# Altium schematic sheet RP2350_QSPI.SchDoc
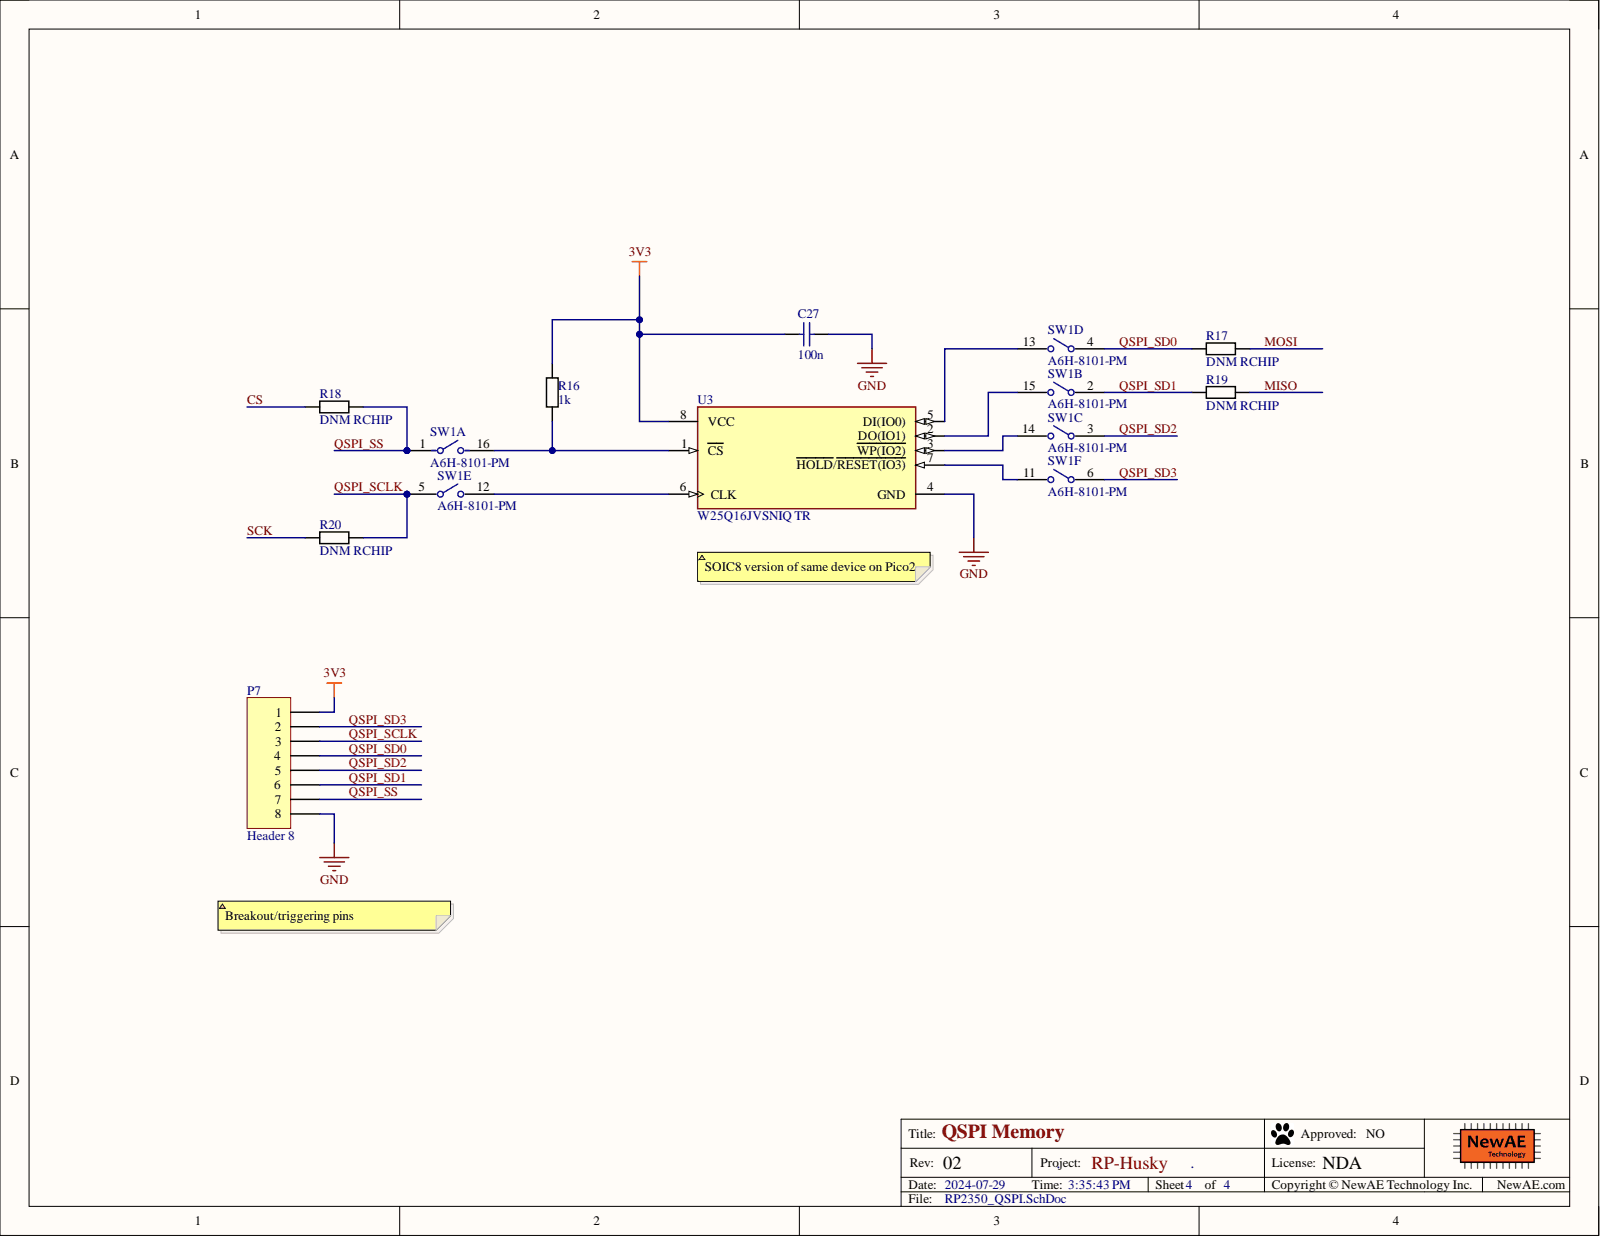
[diagram p0: RP2350_QSPI.SchDoc]

## Parts
C27  100n  [0603]
P7  Header 8  [HDR1X8]
R16  1k  [0603]
R17  DNM RCHIP  [0603]
R18  DNM RCHIP  [0603]
R19  DNM RCHIP  [0603]
R20  DNM RCHIP  [0603]
SW1  A6H-8101-PM  [FP-A6H-8101-PM-MFG]  (parts A,B,C,D,E,F)
U3  W25Q16JVSNIQ TR  [FP-W25Q16JVSNIQ_TR-IPC_A]

## Nets
3V3: C27.1 P7.1 R16.1 U3.8(VCC)
GND: C27.2 P7.8 U3.4(GND)
CS: R18.1
MISO: R19.2
MOSI: R17.2
QSPI_SCLK: P7.3 R20.2 SW1.5
QSPI_SD0: P7.4 R17.1 SW1.4
QSPI_SD1: P7.6 R19.1 SW1.2
QSPI_SD2: P7.5 SW1.3
QSPI_SD3: P7.2 SW1.6
QSPI_SS: P7.7 R18.2 SW1.1
SCK: R20.1
NetR16_2: R16.2 SW1.16 U3.1(CS)
NetSW1_11: SW1.11 U3.7(HOLD/RESET(IO3))
NetSW1_12: SW1.12 U3.6(CLK)
NetSW1_13: SW1.13 U3.5(DI(IO0))
NetSW1_14: SW1.14 U3.3(WP(IO2))
NetSW1_15: SW1.15 U3.2(DO(IO1))

## Library data of the parts
C27 = CCHIP-100n-0603: 100n, 0603; Manufacture 1: Kemet; Manufacture Part Number 1: C0603C104K5RACTU; Case-Metric: 1608; Component Group: Capacitor; Database Table Name: main; Rated Voltage: 50VDC; Case-EIA: 0603; Component Kind: Standard; Component Type: Standard; Library Name: NewAE_AltiumLib.DBLib; Mounting Technology: SMT; Part Number: CCHIP-100n-0603; Pin Count: 2; Supplier 1: Digi-Key; Supplier Part Number 1: 399-5089-2-ND; Value: 100n
P7 = Header 8: Header, 8-Pin; Component Kind: Standard; LatestRevisionDate: 17-Jul-2002; LatestRevisionNote: Re-released for DXP Platform.; Library Name: Miscellaneous Connectors.IntLib; Signal Integrity: Connector; Publisher: Altium Limited
R16 = RCHIP-1K-0603: 1k res, 0603, 1%, 1/10W; Manufacture 1: Yageo; Manufacture Part Number 1: RC0603FR-071KL; Case-Metric: 1608; Component Group: Resistor; Database Table Name: main; Rated Power: 0.1W; Rated Voltage: 75VDC; Case-EIA: 0603; Component Kind: Standard; Component Type: Standard; Library Name: NewAE_AltiumLib.DBLib; Mounting Technology: SMT; Part Number: RCHIP-1K-0603; Pin Count: 2; Supplier 1: Digi-Key; Supplier Part Number 1: 311-1.00KHRCT-ND; Temperature Range: -55C to 155C; Tolerance: 1%; Value: 1k
R17-R20 = RCHIP-DNM-0603: Do Not Mount Resistor; Case-Metric: 1608; Database Table Name: main; Case-EIA: 0603; Component Group: Resistor; Component Kind: Standard; Component Type: Standard; Library Name: NewAE_AltiumLib.DBLib; Mounting Technology: SMT; Part Number: RCHIP-DNM-0603; Pin Count: 2
SW1 = CMP-08827-000045-1: SWITCH SLIDE DIP SPST 25MA 24V; Actuator Type: Slide (Standard); Electrical Life: 1000Cycles; Number of Positions: 8; Pitch: 0.05inch; Washable: No; Component Kind: Standard; Current Rating: 25mA; Depth: 6.7mm; Length: 0.448inch; Library Name: Altium Content Vault; Max Current Rating: 25mA; Max Operating Temperature: 70°C; Max Voltage Rating (DC): 24V; Mechanical Life: 1000Cycles; Min Operating Temperature: -20°C; Mount: Surface Mount; Orientation: Straight; Package Quantity: 4000; Packaging: Tape and Reel; Radiation Hardening: No; Series: A6H; Termination: Gull Wing; Throw Configuration: SPST; Voltage Rating (DC): 24V
U3 = CMP-78876-000009-2: IC FLASH 16MBIT SPI/QUAD 8SOIC; NexarPartId: 75645707; Access Time: 5ms; Interface: SPI; Max Supply Voltage: 3.6V; Memory Size: 2MB; Min Supply Voltage: 2.7V; Ambient Temperature Range High: 85°C; Component Kind: Standard; Height: 1.75mm; Library Name: Altium Content Vault; Max Operating Temperature: 85°C; Memory Type: FLASH; Min Operating Temperature: -40°C; RoHS: Non-Compliant; Schedule B: 8542320070, 8542320070
